# Altium schematic sheet Sheet1.SchDoc
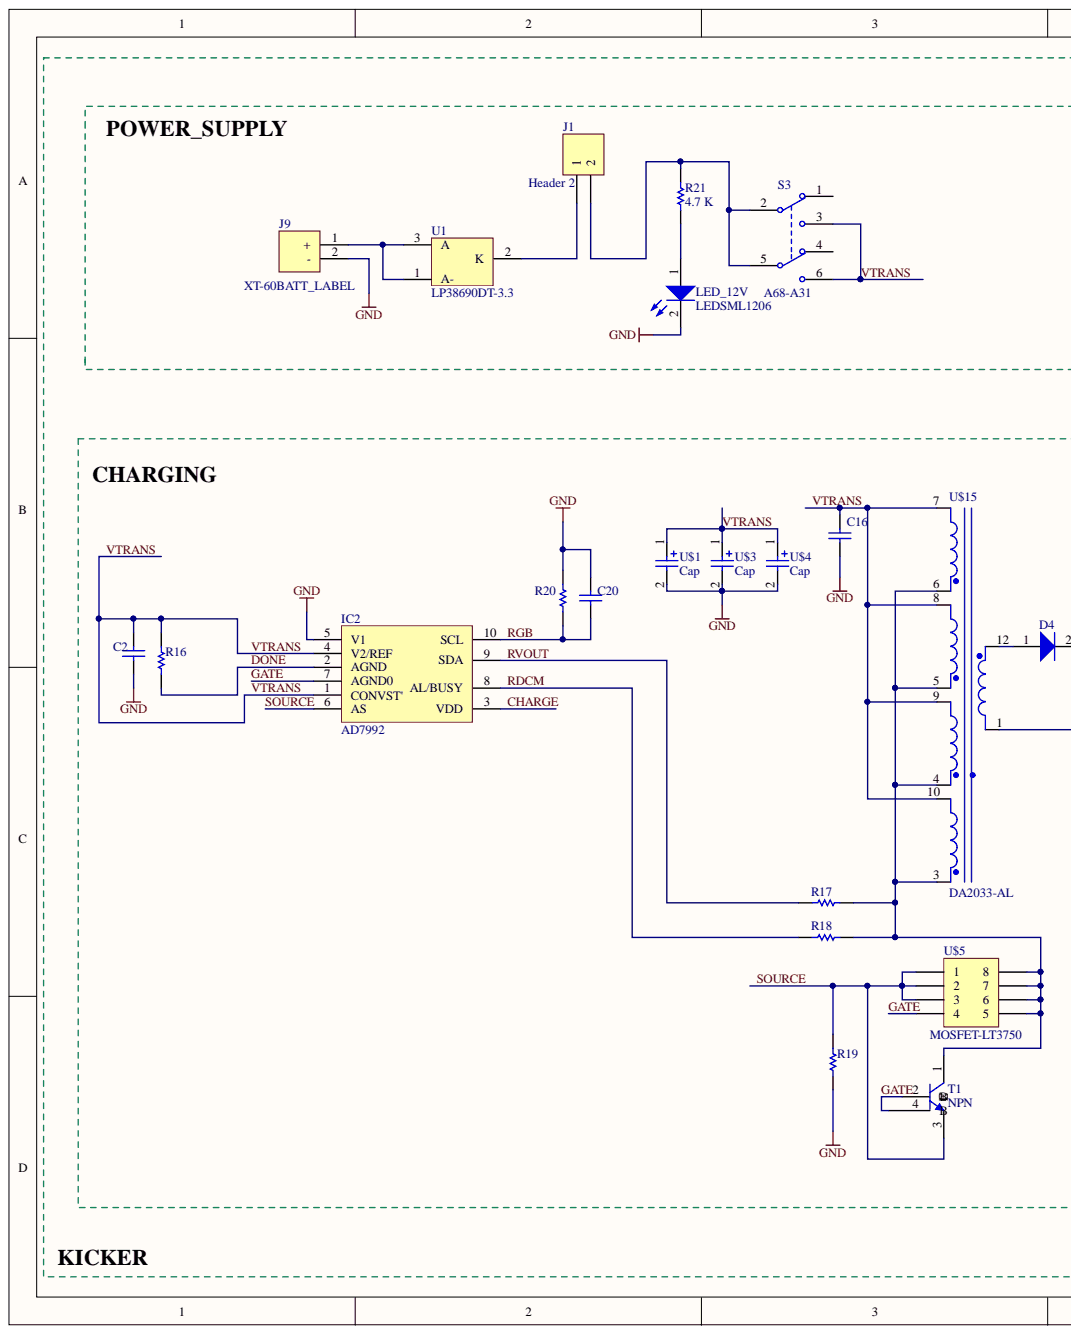
[diagram p0: Sheet1.SchDoc, left]
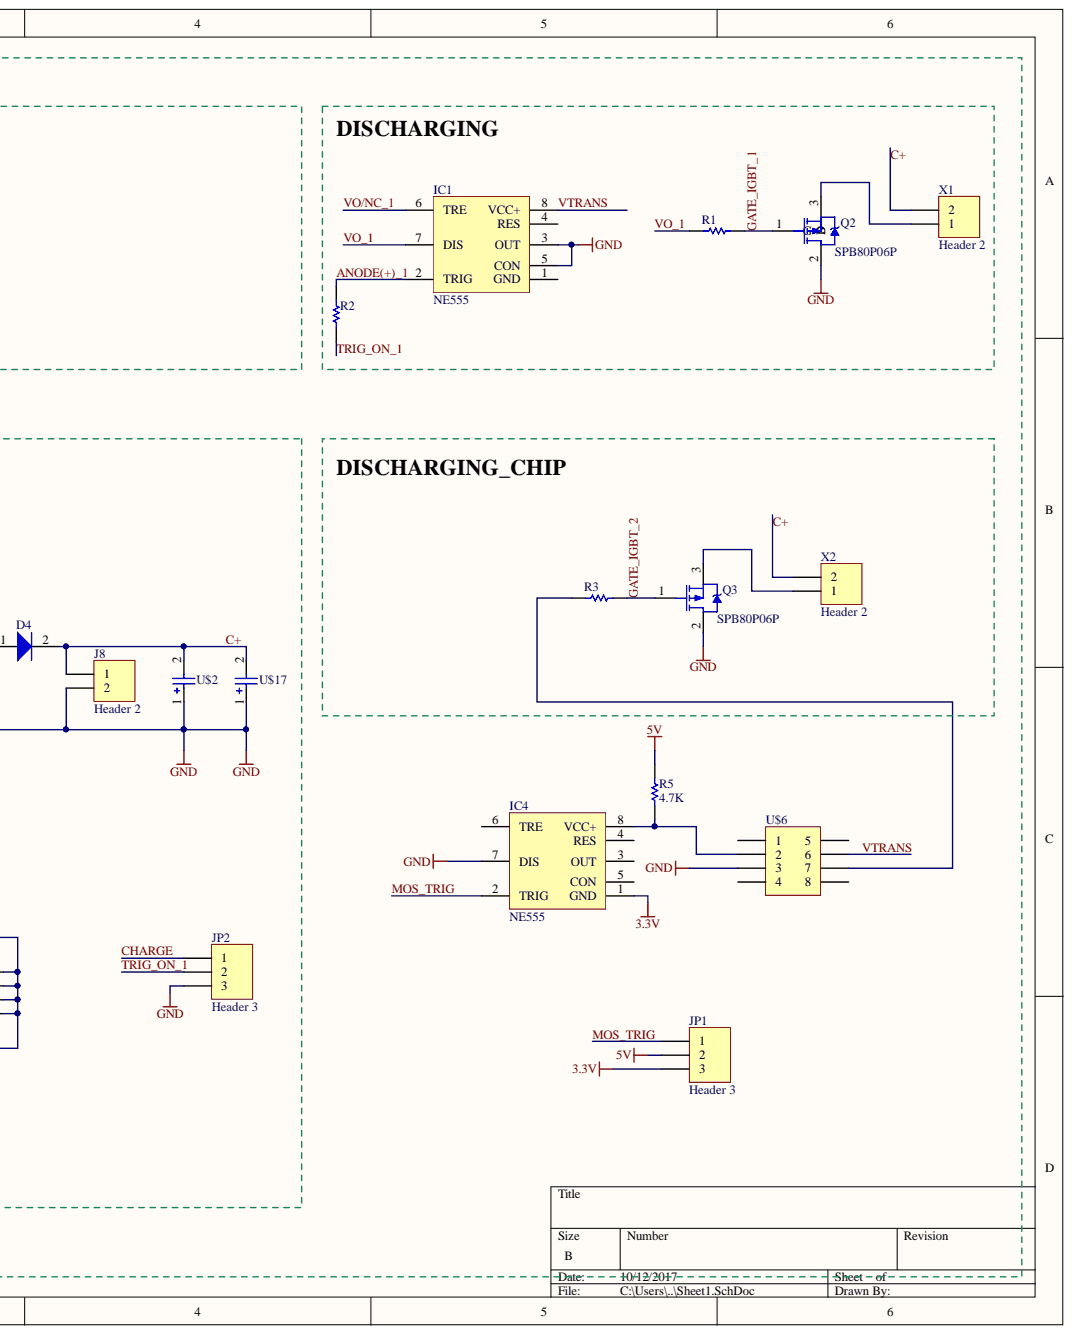
[diagram p1: Sheet1.SchDoc, right]

## Parts
C2  (no value)  [C1206]
C16  (no value)  [C1206]
C20  (no value)  [C1206]
D4  Diode  [DO214AA]  (value not drawn)
IC1  NE555  [DIL-08-IC1,IC4]
IC2  AD7992  [MSOP10-IC2]
IC4  NE555  [DIL-08-IC1,IC4]
J1  Header 2  [HDR1X2]
J8  Header 2  [1X2-3.5MM]
J9  XT-60BATT_LABEL  [XT-60]
JP1  Header 3  [1X03]
JP2  Header 3  [1X03]
LED_12V  LEDSML1206  [SML1206]
Q2  SPB80P06P  [TO263]
Q3  SPB80P06P  [TO263]
R1  (no value)  [R1206]
R2  (no value)  [R1206]
R3  (no value)  [R1206]
R5  4.7K  [0207/10]
R16  4.7 K  [R1206]  (value not drawn)
R17  4.7 K  [R1206]  (value not drawn)
R18  4.7 K  [R1206]  (value not drawn)
R19  4.7 K  [R1206]  (value not drawn)
R20  4.7 K  [R1206]  (value not drawn)
R21  4.7 K  [0207/10]
S3  A68-A31  [A68-A31]
T1  NPN  [SOT223]
U1  LP38690DT-3.3  [TO228P1042X238-3N-U1]
U$1  Cap  [SVP_F12]
U$2  Cap Pol2  [CAP]  (value not drawn)
U$3  Cap  [SVP_F12]
U$4  Cap  [SVP_F12]
U$5  MOSFET-LT3750  [SON-8]
U$6  (no value)  [SOIC-8]
U$15  DA2033-AL  [DA2033-AL-U$15]
U$17  Cap Pol2  [CAP]  (value not drawn)
X1  Header 2  [W237-102]
X2  Header 2  [W237-102]

## Nets
3.3V: IC4.1(GND) JP1.3
5V: JP1.2 R5.1
GND: C2.1 C16.1 C20.2 IC1.3(OUT) IC1.5(CON) IC2.5(V1) IC4.7(DIS) J8.2 J9.2 JP2.3 LED_12V.2 Q2.2 Q3.2 R19.2 R20.2 U$1.2 U$2.1 U$3.2 U$4.2 U$6.3 U$15.1 U$17.1
ANODE(+)_1: IC1.2(TRIG) R2.2
C+: D4.2 J8.1 U$2.2 U$17.2 X1.2 X2.2
CHARGE: IC2.3(VDD) JP2.1
DONE: IC2.2(AGND) R16.1
GATE: IC2.7(AGND0) T1.2 T1.4(B) U$5.4
GATE_IGBT_1: Q2.1 R1.1
GATE_IGBT_2: Q3.1 R3.1
MOS_TRIG: IC4.2(TRIG) JP1.1
RDCM: IC2.8(AL/BUSY) R18.2
RGB: C20.1 IC2.10(SCL) R20.1
RVOUT: IC2.9(SDA) R17.2
SOURCE: IC2.6(AS) R19.1 T1.3(B) U$5.1 U$5.2 U$5.3
TRIG_ON_1: JP2.2 R2.1
VO/NC_1: IC1.6(TRE)
VO_1: IC1.7(DIS) R1.2
VTRANS: C2.2 C16.2 IC1.8(VCC+) IC2.1(CONVST') IC2.4(V2/REF) R16.2 S3.3 S3.6 U$1.1 U$3.1 U$4.1 U$6.6 U$15.7 U$15.8 U$15.9 U$15.10
NetD4_1: D4.1 U$15.12
NetIC4_8: IC4.8(VCC+) R5.2 U$6.2
NetJ1_1: J1.1 U1.2
NetJ1_2: J1.2 R21.2 S3.2 S3.5
NetJ9_1: J9.1 U1.1 U1.3
NetLED_12V_1: LED_12V.1 R21.1
NetQ2_3: Q2.3 X1.1
NetQ3_3: Q3.3 X2.1
NetR3_2: R3.2 U$6.7
NetR17_1: R17.1 R18.1 T1.1 U$5.5 U$5.6 U$5.7 U$5.8 U$15.3 U$15.4 U$15.5 U$15.6

Not connected: IC1 1(GND) 4(RES); IC4 3(OUT) 4(RES) 5(CON) 6(TRE); S3 1 4; U$6 1 4 5 8

## Library data of the parts
C2 C16 C20 = Cap: Capacitor; Value: 100pF; Component Kind: Standard; LatestRevisionDate: 17-Jul-2002; LatestRevisionNote: Re-released for DXP Platform.; Library Name: Miscellaneous Devices.IntLib; PackageDescription: Radial Cap, Thru-Hole; 2 Leads; 0.3 in Pin Spacing; PackageReference: RAD-0.3; Published: 8-Jun-2000; Signal Integrity: Cap; Simulation: CAP; Publisher: Altium Limited
D4 = Diode: Default Diode; Code_JEDEC: DO-214-AB; Component Kind: Standard; LatestRevisionDate: 17-Jul-2002; LatestRevisionNote: Re-released for DXP Platform.; Library Name: Miscellaneous Devices.IntLib; PackageDescription: DO-214-AB/SMC; 2 C-Bend Leads; Body 7.9 x 5.9 mm, inc. leads (LxW); PackageReference: SMC; PackageVersion: Sep-1996; Published: 8-Jun-2000; Signal Integrity: Diode; Simulation: DIODE; Publisher: Altium Limited
IC1 IC4 = Component_2: Component Kind: Standard; Library Name: Schlib1.SchLib
IC2 = Component_3: Component Kind: Standard; Library Name: Schlib1.SchLib
J1 J8 J9 X1 X2 = Header 2: Header, 2-Pin; Component Kind: Standard; LatestRevisionDate: 17-Jul-2002; LatestRevisionNote: Re-released for DXP Platform.; Library Name: Miscellaneous Connectors.IntLib; Signal Integrity: Connector; Publisher: Altium Limited
JP1 JP2 = Header 3: Header, 3-Pin; Component Kind: Standard; LatestRevisionDate: 17-Jul-2002; LatestRevisionNote: Re-released for DXP Platform.; Library Name: Miscellaneous Connectors.IntLib; Signal Integrity: Connector; Publisher: Altium Limited
LED_12V = LED0: Typical INFRARED GaAs LED; Component Kind: Standard; LatestRevisionDate: 08-Jul-2005; LatestRevisionNote: Mech Layer 1 removed.; Library Name: Miscellaneous Devices.IntLib; PackageDescription: LED; 2 Leads; PackageReference: LED-0; Published: 8-Jun-2000; Simulation: LED0; Publisher: Altium Limited
Q2 Q3 = PMOS-2: P-Channel Power MOSFET; Note: Pin 4 not drawn and electrically connected to Pin 2.; Component Kind: Standard; ComponentLink1Description: Manufacturer Link; ComponentLink1URL: http://www.irf.com/; DatasheetVersion: PD-9.390D; LatestRevisionDate: 17-Jul-2002; LatestRevisionNote: Re-released for DXP Platform.; Library Name: Miscellaneous Devices.IntLib; PackageDescription: TO, Thru-Hole, Vertical, Heatsink Mounted; 3 In-Line Leads; Pitch 2.54 mm; PackageReference: TO-220AB; PackageVersion: Feb-1997; Published: 8-Jun-2000; Simulation: IRF9510; Publisher: Altium Limited
R1-R3 R5 R16-R21 = Res Semi: Semiconductor Resistor; Value: 1K; Component Kind: Standard; LatestRevisionDate: 17-Jul-2002; LatestRevisionNote: Re-released for DXP Platform.; Library Name: Miscellaneous Devices.IntLib; PackageDescription: Axial Device, Thru-Hole; 2 Leads; 0.5 in Pin Spacing; PackageReference: AXIAL-0.5; Published: 8-Jun-2000; Signal Integrity: Res Semi; Simulation: RES; Publisher: Altium Limited
S3 = SW DPDT: Switch; Code_IPC: SOT26A-6; Code_JEITA: SC-74; Component Kind: Standard; LatestRevisionDate: 29-May-2009; LatestRevisionNote: IPC-7351 Footprint Added.; Library Name: Miscellaneous Devices.IntLib; PackageDescription: 6-Pin SOT-23 Package 0.95 mm Pitch, 2.7 mm Lead Span; PackageReference: SOT23-6; PackageVersion: Mar-2001; Published: 24-Mar-1999; Publisher: Altium Limited
T1 = NPN: NPN Bipolar Transistor; Code_JEDEC: TO-226-AA; Component Kind: Standard; LatestRevisionDate: 17-Jul-2002; LatestRevisionNote: Re-released for DXP Platform.; Library Name: Miscellaneous Devices.IntLib; PackageDescription: TO, Flat Index; 3 In-Line, Axial Leads; Body Dia. 4.8mm; Leads 0.55 x 0.51 mm (max); PackageReference: TO-226-AA; Published: 8-Jun-2000; Signal Integrity: NPN; Simulation: NPN; Publisher: Altium Limited
U1 = Component_1: Component Kind: Standard; Library Name: Schlib1.SchLib
U$1-U$4 U$17 = Cap Pol2: Polarized Capacitor (Axial); Value: 100pF; Component Kind: Standard; LatestRevisionDate: 17-Jul-2002; LatestRevisionNote: Re-released for DXP Platform.; Library Name: Miscellaneous Devices.IntLib; PackageDescription: Polarized Capacitor; 2 Leads; PackageReference: POLAR0.8; Published: 8-Jun-2000; Signal Integrity: Cap Pol; Simulation: CAP; Publisher: Altium Limited
U$5 U$6 = Header 4X2A: Header, 4-Pin, Dual row; Component Kind: Standard; LatestRevisionDate: 17-Jul-2002; LatestRevisionNote: Re-released for DXP Platform.; Library Name: Miscellaneous Connectors.IntLib; Signal Integrity: Connector; Publisher: Altium Limited
U$15 = Component_5: Component Kind: Standard; Library Name: Schlib1.SchLib
